# Altium schematic sheet Bandgap Reference.SchDoc("Bandgap Reference")
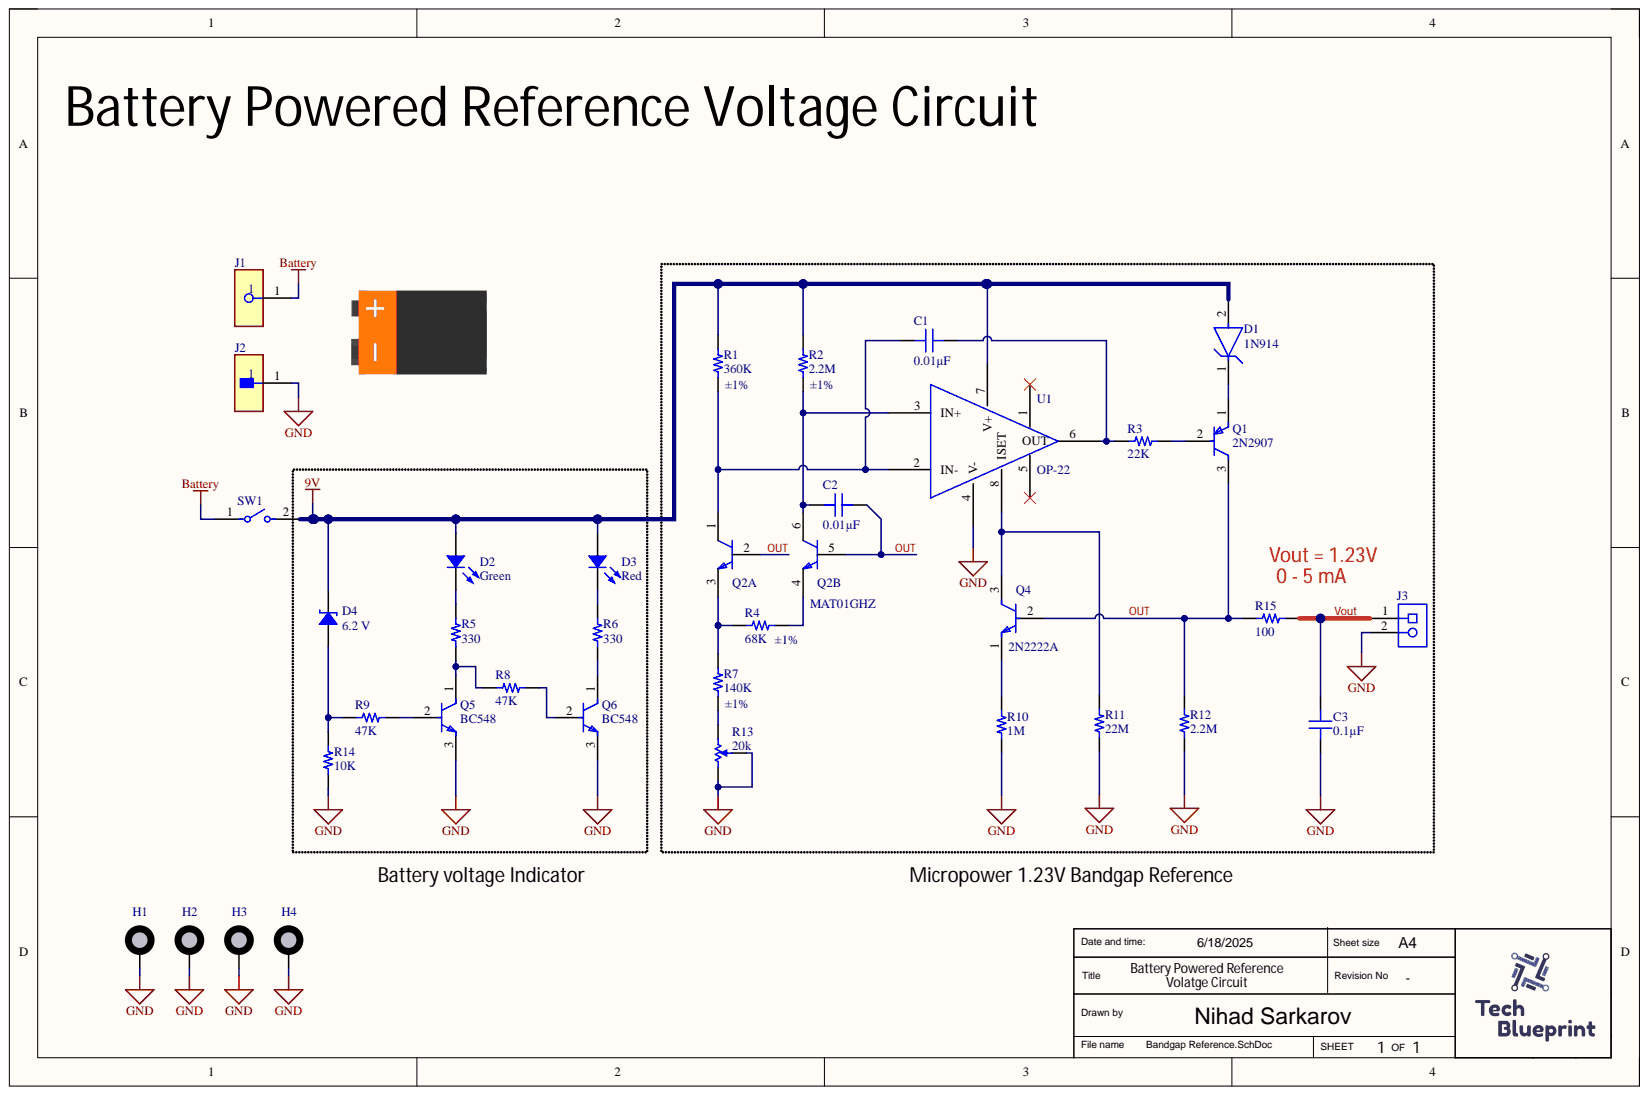
[diagram p0: Bandgap Reference.SchDoc]

## Parts
C1  Capacitance: 0.01µF  [CAP 1206_3216 - 0.8MM]  (hidden comment: 10000 pF)
C2  Capacitance: 0.01µF  [CAP 1206_3216 - 0.8MM]  (hidden comment: 10000 pF)
C3  0.1µF  [CAP 1206_3216 - 1.0MM]
D1  1N914  [FP-DO-213AA-MFG]
D2  Green  [LED 1206_3216 GREEN]
D3  Red  [LED 1206_3216 RED]
D4  Voltage - Zener (Nom) (Vz): 6.2 V  [DIODES SOT-323-3]  (hidden comment: BZX84W-B6V2F)
H1  Mounting Hole  [Mounting Hole]  (value not drawn)
H2  Mounting Hole  [Mounting Hole]  (value not drawn)
H3  Mounting Hole  [Mounting Hole]  (value not drawn)
H4  Mounting Hole  [Mounting Hole]  (value not drawn)
J1  594  [FP-594-MFG]  (value not drawn)
J2  593  [FP-593-MFG]  (value not drawn)
J3  1729128  [PHOE-1729128-2_V]  (value not drawn)
Q1  2N2907  [FP-TO-18-MFG]
Q2  MAT01GHZ  [FP-H-06-MFG]  (parts A,B)
Q4  2N2222A  [FP-2N2222A-MFG]
Q5  BC548  [FP-135AN-MFG]
Q6  BC548  [FP-135AN-MFG]
R1  360K  Tolerance: ±1%  [RES 1206_3216]
R2  2.2M  Tolerance: ±1%  [RES 1206_3216]
R3  22K  [RES 1206_3216]
R4  68K  Tolerance: ±1%  [RES 1206_3216]
R5  330  [RES 1206_3216]
R6  330  [RES 1206_3216]
R7  140K  Tolerance: ±1%  [RES 1206_3216]
R8  47K  [RES 1206_3216]
R9  47K  [RES 1206_3216]
R10  1M  [RES 1206_3216]
R11  22M  [RES 1206_3216]
R12  2.2M  [RES 1206_3216]
R13  Resistance: 20k  [BOUR-3313J-1_V]  (hidden comment: 3313J-1-203E)
R14  10K  [RES 1206_3216]
R15  100  [RES 1206_3216]
SW1  BD01  [FP-BD01-MFG]  (value not drawn)
U1  OP-22  [CERDIP8_300MC_MCH]

## Nets
9V: D1.2 D2.1 D3.1 D4.2 R1.2 R2.2 SW1.2 U1.7(V+)
Battery: J1.1 SW1.1
GND: C3.1 H1.1 H2.1 H3.1 H4.1 J2.1 J3.2 Q5.3 Q6.3 R10.1 R11.1 R12.1 R13.1 R13.2 R14.1 U1.4(V-)
OUT: C2.2 Q1.3 Q2.2 Q2.5 Q4.2 R12.2 R15.1
Vout: C3.2 J3.1 R15.2
NetC1_1: C1.1 Q2.1 R1.1 U1.2(IN-)
NetC1_2: C1.2 R3.1 U1.6(OUT)
NetC2_1: C2.1 Q2.6 R2.1 U1.3(IN+)
NetD1_1: D1.1 Q1.1
NetD2_2: D2.2 R5.2
NetD3_2: D3.2 R6.2
NetD4_1: D4.1 R9.1 R14.2
NetQ1_2: Q1.2 R3.2
NetQ2_3: Q2.3 R4.1 R7.2
NetQ2_4: Q2.4 R4.2
NetQ4_1: Q4.1 R10.2
NetQ4_3: Q4.3 R11.2 U1.8(ISET)
NetQ5_1: Q5.1 R5.1 R8.1
NetQ5_2: Q5.2 R9.2
NetQ6_1: Q6.1 R6.1
NetQ6_2: Q6.2 R8.2
NetR7_1: R7.1 R13.3

Not connected: U1 1 5

## Library data of the parts
C1 C2 = C1206C103KARECAUTO: CAP CER 1206 10NF 250V X7R 10%; manufacturer: KEMET; Database Table Name: Capacitors - Ceramic - 1206; Applications: Automotive, ESD Protection; Component Kind: Standard; componentlink1description: Datasheet; componentlink1url: https://api.kemet.com/component-edge/download/datasheet/C1206C103KARECAUTO.pdf; componentlink2description: DigiKey Link; componentlink2url: https://www.digikey.com/en/products/detail/kemet/C1206C103KARECAUTO/8563702; Device Package: 1206 (3216 Metric); lastupdated: 6/4/2024 7:10:29 PM; Library Name: Celestial Altium Library - altium_library.DbLib; Lifecycle Status: Active; Minimum Order: 1; Mounting Type: Surface Mount, MLCC; Operating Temperature: -55°C ~ 125°C; Package / Case: 1206 (3216 Metric); packaging: TapeAndReel; Part Number: C1206C103KARECAUTO; Part Status: Active; partid: 20579; price: 0.03; Ratings: AEC-Q200; series: ESD SMD Auto X7R; Size / Dimension: 0.126" L x 0.063" W (3.20mm x 1.60mm); Supplier 1: DigiKey; Supplier Part Number 1: 399-17174-1-ND; Temperature Coefficient: X7R; Thickness (Max): 0.035" (0.88mm); Tolerance: ±10%; value: 10000 pF; Voltage - Rated: 250V
C3 = LD065C104KAB2A: CAP CER 0.1UF 50V X7R 1206; manufacturer: AVX Corporation; Database Table Name: Capacitors - Ceramic - 1206; Tolerance: ±10%; Applications: General Purpose; Capacitance: 0.1µF; Component Kind: Standard; componentlink1description: Datasheet; componentlink1url: http://datasheets.avx.com/LD-Series.pdf; componentlink2description: DigiKey Link; componentlink2url: http://digikey.com/product-detail/en/avx-corporation/LD065C104KAB2A/478-4932-1-ND/1858878; Device Package: 1206 (3216 Metric); lastupdated: 9/2/2020 1:05:06 AM; Library Name: Celestial Altium Library - altium_library.DbLib; Lifecycle Status: Active; Minimum Order: 1; Mounting Type: Surface Mount, MLCC; Operating Temperature: -55°C ~ 125°C; Package / Case: 1206 (3216 Metric); packaging: TapeAndReel; Part Number: LD065C104KAB2A; Part Status: Active; partid: 18962; price: 0.15; series: LD; Size / Dimension: 0.126" L x 0.063" W (3.20mm x 1.60mm); Supplier 1: DigiKey; Supplier Part Number 1: 478-4932-1-ND; Temperature Coefficient: X7R; Thickness (Max): 0.037" (0.94mm); value: 0.1µF; Voltage - Rated: 50V
D1 = CMP-00252-10456399-1: JANTX1N914UR Signal Or Computer Diode Do-213Aa Rohs Compliant: Yes; Average Rectified Current: 200mA; Capacitance: 4pF; Element Configuration: Single; Forward Voltage: 1.2V; Max Forward Surge Current (Ifsm): 2A; Max Junction Temperature (Tj): 175°C; Max Repetitive Reverse Voltage (Vrrm): 75V; Peak Reverse Current: 25nA; Reverse Recovery Time: 5ns; Component Kind: Standard; Forward Current: 200mA; Height: 1.7mm; Library Name: Altium Content Vault; Lifecycle Status: Production; Max Operating Temperature: 175°C; Max Reverse Voltage (DC): 75V; Min Operating Temperature: -65°C; Mount: Surface Mount; NexarPartId: 10456399; Number of Pins: 2; Peak Non-Repetitive Surge Current: 2A; Radiation Hardening: No; Reverse Voltage: 75V; RoHS: Compliant; Schedule B: 8541100070
D2 = APT3216SGC: LED GREEN CLEAR CHIP SMD; Manufacturer: Kingbright; Configuration: Standard; Current - Test: 20mA; Database Table Name: LED - Indication; Lens Color: Colorless; Lens Size: 2.00mm x 1.60mm; Lens Style: Rectangle with Flat Top; Millicandela Rating: 12mcd; Viewing Angle: 120°; Voltage - Forward (Vf) (Typ): 2.2V; Wavelength - Dominant: 568nm; Wavelength - Peak: 565nm; Color: Green; Component Kind: Standard; ComponentLink1Description: Datasheet; ComponentLink1URL: http://www.kingbrightusa.com/images/catalog/SPEC/APT3216SGC.pdf; ComponentLink2Description: DigiKey Link; ComponentLink2URL: https://www.digikey.com/en/products/detail/kingbright/APT3216SGC/1747540; Device Package: 1206 (3216 Metric); Height (Max): 0.75mm; LastUpdated: 6/3/2024 7:59:11 PM; Lens Transparency: Clear; Library Name: Celestial Altium Library - altium_library.DbLib; Lifecycle Status: Active; Minimum Order: 1; Mounting Type: Surface Mount; Package / Case: 1206 (3216 Metric); Packaging: TapeAndReel; Part Number: APT3216SGC; Part Status: Active; PartId: 526; Price: 0.05; Series: -; Size / Dimension: 3.20mm L x 1.60mm W; Supplier 1: DigiKey; Supplier Device Package: Chip LED; Supplier Part Number 1: 754-1141-1-ND; Value: Green
D3 = HSMC-C350: LED RED CHIP SMD; Manufacturer: Broadcom Limited; Configuration: Standard; Current - Test: 20mA; Database Table Name: LED - Indication; Lens Color: 1.20mm; Lens Size: 1.10mm Dia; Lens Style: Round with Domed Top; Viewing Angle: 70°; Voltage - Forward (Vf) (Typ): 2V; Wavelength - Dominant: 630nm; Wavelength - Peak: 643nm; Color: Red; Component Kind: Standard; ComponentLink1Description: Datasheet; ComponentLink1URL: https://docs.broadcom.com/docs/HSMC-C350-DS100; ComponentLink2Description: DigiKey Link; ComponentLink2URL: https://www.digikey.com/en/products/detail/broadcom-limited/HSMC-C350/8509678; Device Package: 1206 (3216 Metric); Height (Max): 1.20mm; LastUpdated: 6/3/2024 8:00:41 PM; Library Name: Celestial Altium Library - altium_library.DbLib; Lifecycle Status: Active; Minimum Order: 1; Mounting Type: Surface Mount; Package / Case: 1206 (3216 Metric); Packaging: TapeAndReel; Part Number: HSMC-C350; Part Status: Active; PartId: 195; Price: 0.26; Series: -; Size / Dimension: 3.20mm L x 1.60mm W; Supplier 1: DigiKey; Supplier Device Package: Chip LED; Supplier Part Number 1: 516-4369-1-ND; Value: Red
D4 = BZX84W-B6V2F: DIODE ZENER 6.2V 275MW SOT323; Manufacturer: Nexperia USA Inc.; Current - Reverse Leakage @ Vr: 3 µA @ 4 V; Database Table Name: Diodes - Zener - Single; Impedance (Max) (Zzt): 10 Ohms; Tolerance: ±2%; Voltage - Forward (Vf) (Max) @ If: 900 mV @ 10 mA; Component Kind: Standard; ComponentLink1Description: Datasheet; ComponentLink1URL: https://assets.nexperia.com/documents/data-sheet/BZX84W_SER.pdf; ComponentLink2Description: DigiKey Link; ComponentLink2URL: https://www.digikey.com/en/products/detail/nexperia-usa-inc/BZX84W-B6V2F/9380695; LastUpdated: 6/3/2024 8:01:47 PM; Library Name: Celestial Altium Library - altium_library.DbLib; Lifecycle Status: Active; Minimum Order: 1; Mounting Type: Surface Mount; Operating Temperature: 150°C (TJ); Package / Case: SC-70, SOT-323; Packaging: TapeAndReel; Part Number: BZX84W-B6V2F; Part Status: Active; PartId: 809; Power - Max: 275 mW; Price: 0.02; Series: BZX84W; Supplier 1: DigiKey; Supplier Device Package: SOT-323; Supplier Part Number 1: 1727-8280-1-ND
J1 = CMP-19636-000029-1: BATTERY CONTACT SNAP 9V PC PIN; Body Material: Steel; Body Plating: Tin; Connector Type: Snap in; Gender: Female; Material Thickness: 0.15inch; Number of Batteries: 1; Component Kind: Standard; Contact Material: Steel; Contact Plating: Nickel; Contact Type: Snap-In; Lead Free: Lead Free; Length: 9.65mm; Library Name: Altium Content Vault; Material: Steel; Mount: Through Hole; Number of Cells: 1; Orientation: Right Angle; Package Quantity: 100; Packaging: Bulk; Reach SVHC Compliant: Yes; RoHS Compliant: Yes; Series: -; Termination: PC Pin; Thickness: 0.015inch
J2 = CMP-19636-000031-1: BATTERY CONTACT SNAP 9V PC PIN; Body Material: Steel; Body Plating: Tin; Connector Type: Snap in; Gender: Male; Material Thickness: 0.15inch; Number of Batteries: 1; Component Kind: Standard; Contact Material: Steel; Contact Plating: Nickel; Contact Type: Snap-In; Lead Free: Lead Free; Length: 9.65mm; Library Name: Altium Content Vault; Material: Steel; Mount: Through Hole; Number of Cells: 1; Orientation: Right Angle; Package Quantity: 100; Packaging: Bulk; Reach SVHC Compliant: Yes; RoHS Compliant: Yes; Series: -; Termination: PC Pin; Thickness: 0.015inch
J3 = CMP-2000-05815-1: PCB Terminal Block, 13.5 A, 400 V, 2-Pin THD, RoHS, Bulk; Manufacturer: Phoenix Contact; Current Rating: 13500mA; No. of Positions: 2; No. of Rows: 1; Package Version: 03/2015; Pitch: 5.08mm; Voltage Rating: 400V; Component Kind: Standard; Datasheet URL: https://datasheet.ciiva.com/16046/1729128-16046181.pdf; Datasheet Version: 12/2013; Library Name: Altium Content Vault; Manufacturer URL: http://www.phoenixcontact.com/; Mounting Technology: ThroughHole; Orientation: Horizontal; Package Description: 2-Pin Through Hole Device, Body 8.1 x 10.16 mm, Pitch 5.08 mm; Package Reference: 1729128-2; Package URL: https://www.phoenixcontact.com/online/portal/pi/pxc/product_detail_page/!ut/p/b1/3VdJjqNKFDxLH8AFycySyWY2ozFsEPOMMQaDOX1XedGL_1Vdm5YXJleknhSKeBkvI6EA8ncAo3AYoDhBQGco6KN7VURTdemj9us_IEKEZo_YHiPAEWEFmDGOHMORkqKYCORBZxgL7ZoaZYcbFFUZ3fqxSY6GOuxydWUuHqz1ynmMFygu583Bob0Ewr6Sd_l-5m-kAueHiB0jpRwZwe58wwtkpxtMYd_LmGcDRU02dRNEyWt6Y2ti5pSyJ_reIkzIulrqApdnbT11V5jnCKbYNCvhEM1MCpPByat_X379-iThf08CI5GfOPqfBeS3BRoBOdD55AKVv0iLwCMq-2BrzXWSGRH7pUGD9uwXQjiph3xL0DKQwdGa0JImiIOmBNOestqgwTHSSs2oOep-78apyXQBvNi8ZLaFjvjWvcaUEBu0MC6Wbe-d6mB0FAebo2lUWinqYaZs5DAYzV0RP9yitUjnGJ0iI9xhZkT42OCQNUJJhkgWINNQLP2JFP6OpMg3JCVg70jqHT0lvKOnhHf0lPuOnnLf0VPuWx6_dxwUIvwk5WMkT0kLL1mh61l2yZVSzG3pYV_bqo-mj6uMKwKwdnnW8Jh5RTOJzMOA5c-eJDaZQw4mTMPH02PvS_ZAqNVjVTo2WyYLkwH_KU1mmmG5kjRhDqPXLwwVHvVOjCM60-fpXvTDfMGW1PVFVS1r4e4yVDk4ZdNbkUHvqPqQSeian4UD39fNKmachqdJ1NJbyk8rXT9mXTvPk3oE5meulKGgiruPJek-4I9hTT6G8ZLOyRSm2RRVbThERQad5OS28hdt4YW4vG0stgYYzsWsK4_7y6DZMjJSCqri1kyiZR5vD7pwIrNR7QwPHTcLTI5qT7eRlpHrdGiupYlqQDJ7zy_CND8fWWBoLRXxk1RVcXiBQwbTD4Xi7KL-FuO94t9xaqweXzH; Packing: Bulk; PartNumber: 1729128; RoHS: TRUE
Q1 = CMP-00252-117134470-1: 2N2907 Small-Signal Bjt To-18 Rohs Compliant: Yes; Collector Base Voltage (VCBO): 60V; Collector Emitter Saturation Voltage: 1.6V; Emitter Base Voltage (VEBO): 5V; hFE Min: 100; Max Collector Current: 600mA; Max Junction Temperature (Tj): 200°C; Number of Elements: 1; Power Dissipation: 500mW; Case/Package: TO-18; Collector Emitter Breakdown Voltage: 60V; Collector Emitter Voltage (VCEO): 60V; Component Kind: Standard; Height: 5.33mm; Library Name: Altium Content Vault; Lifecycle Status: Production; Max Operating Temperature: 200°C; Min Operating Temperature: -65°C; NexarPartId: 117134470
Q2 = MAT01GHZ: TRANS 2NPN 45V 0.025A TO78-6; Element Configuration: Dual; hFE Min: 250; Max Collector Current: 25mA; Max Power Dissipation: 500mW; Transition Frequency: Mhz; Case/Package: TO-78; Collector Emitter Voltage (VCEO): 45V; Component Kind: Standard; Height: 4.7mm; Library Name: TC427IJA.SchLib; Max Operating Temperature: 125°C; Min Operating Temperature: -55°C; RoHS Compliant: Yes
Q4 = CMP-50385-000001-1: T-NPN SI- AUDIO VHF AMP; Collector Emitter Breakdown Voltage: 40V; Max Power Dissipation: 1.2W; Polarity: NPN; Transition Frequency: 300MHz; Case/Package: TO-18; Collector Emitter Voltage (VCEO): 40V; Component Kind: Standard; Lead Free: Contains Lead; Library Name: Altium Content Vault; Max Operating Temperature: 200°C; Number of Pins: 3; REACH SVHC: No SVHC
Q5 Q6 = CMP-00278-84324557-1: BC548 Bulk Through Hole NPN Single Bipolar (BJT) Transistor 110 @ 2mA 5V 100mA 500mW 300MHz; Collector Base Voltage (VCBO): 30V; Collector Emitter Saturation Voltage: 250mV; hFE Min: 110; Number of Elements: 1; Case/Package: TO-92; Collector Emitter Breakdown Voltage: 30V; Collector Emitter Voltage (VCEO): 30V; Component Kind: Standard; Current Rating: 100mA; Element Configuration: Single; Emitter Base Voltage (VEBO): 5V; Frequency: 300MHz; Gain Bandwidth Product: 300MHz; Lead Free: Lead Free; Library Name: Altium Content Vault; Max Collector Current: 100mA; Max Frequency: 300MHz; Max Operating Temperature: 150°C; Max Power Dissipation: 500mW; Min Operating Temperature: -65°C; Mount: Through Hole; NexarPartId: 84324557; Number of Pins: 3; Packaging: Bulk; Polarity: NPN; Power Dissipation: 500mW; Radiation Hardening: No; REACH SVHC: No SVHC; RoHS: Compliant; Termination: Through Hole; Transition Frequency: 300MHz; Voltage Rating (DC): 30V; Weight: 200mg
R1 = 3430B2F360KTDF: 3430 B2 1% 360K 100PPM 1K RL; Manufacturer: TE Connectivity Passive Product; Database Table Name: Resistors - Surface Mount - 1206; Power (Watts): 1.5W; Resistance: 360 kOhms; Temperature Coefficient: ±100ppm/°C; Value: 360 kOhms; Component Kind: Standard; ComponentLink2Description: DigiKey Link; ComponentLink2URL: https://www.digikey.com/en/products/detail/te-connectivity-passive-product/3430B2F360KTDF/17764161; Composition: Thick Film; Device Package: 1206 (3216 Metric); Features: Automotive AEC-Q200; Height - Seated (Max): 0.026" (0.65mm); LastUpdated: 2/9/2024 8:52:53 PM; Library Name: Celestial Altium Library - altium_library.DbLib; Lifecycle Status: Active; Minimum Order: 1; Number of Terminations: 2; Operating Temperature: -55°C ~ 155°C; Package / Case: 1206 (3216 Metric); Packaging: TapeAndReel; Part Number: 3430B2F360KTDF; Part Status: Active; PartId: 77146; Price: 0.07; Ratings: AEC-Q200; Series: 3430; Size / Dimension: 0.061" L x 0.118" W (1.55mm x 3.00mm); Supplier 1: DigiKey; Supplier Device Package: 0612; Supplier Part Number 1: 1712-3430B2F360KTDFCT-ND
R2 R12 = ERJ-PM8F2204V: RES 2.2M OHM 1% 0.66W 1206; Manufacturer: Panasonic Electronic Components; Database Table Name: Resistors - Surface Mount - 1206; Power (Watts): 0.667W, 2/3W; Resistance: 2.2 MOhms; Temperature Coefficient: ±100ppm/°C; Value: 2.2 MOhms; Component Kind: Standard; ComponentLink1Description: Datasheet; ComponentLink1URL: https://industrial.panasonic.com/cdbs/www-data/pdf/RDO0000/AOA0000C331.pdf; ComponentLink2Description: DigiKey Link; ComponentLink2URL: https://www.digikey.com/en/products/detail/panasonic-electronic-components/ERJ-PM8F2204V/16265782; Composition: Thick Film; Device Package: 1206 (3216 Metric); Features: Automotive AEC-Q200, Pulse Withstanding; Height - Seated (Max): 0.028" (0.70mm); LastUpdated: 2/9/2024 8:53:14 PM; Library Name: Celestial Altium Library - altium_library.DbLib; Lifecycle Status: Active; Minimum Order: 1; Number of Terminations: 2; Operating Temperature: -55°C ~ 155°C; Package / Case: 1206 (3216 Metric); Packaging: TapeAndReel; Part Number: ERJ-PM8F2204V; Part Status: Active; PartId: 68714; Price: 0.03; Ratings: AEC-Q200; Series: ERJ-PM8; Size / Dimension: 0.126" L x 0.063" W (3.20mm x 1.60mm); Supplier 1: DigiKey; Supplier Device Package: 1206; Supplier Part Number 1: 10-ERJ-PM8F2204VCT-ND
  R12: Tolerance: ±1%
R3 = 3430B2F22KTDF: 3430 B2 1% 22K 100PPM 1K RL; Manufacturer: TE Connectivity Passive Product; Database Table Name: Resistors - Surface Mount - 1206; Power (Watts): 1.5W; Resistance: 22 kOhms; Temperature Coefficient: ±100ppm/°C; Value: 22 kOhms; Component Kind: Standard; ComponentLink2Description: DigiKey Link; ComponentLink2URL: https://www.digikey.com/en/products/detail/te-connectivity-passive-product/3430B2F22KTDF/17763028; Composition: Thick Film; Device Package: 1206 (3216 Metric); Features: Automotive AEC-Q200; Height - Seated (Max): 0.026" (0.65mm); LastUpdated: 2/9/2024 8:52:52 PM; Library Name: Celestial Altium Library - altium_library.DbLib; Lifecycle Status: Active; Minimum Order: 1; Number of Terminations: 2; Operating Temperature: -55°C ~ 155°C; Package / Case: 1206 (3216 Metric); Packaging: TapeAndReel; Part Number: 3430B2F22KTDF; Part Status: Active; PartId: 77235; Price: 0.07; Ratings: AEC-Q200; Series: 3430; Size / Dimension: 0.061" L x 0.118" W (1.55mm x 3.00mm); Supplier 1: DigiKey; Supplier Device Package: 0612; Supplier Part Number 1: 1712-3430B2F22KTDFCT-ND; Tolerance: ±1%
R4 = 3430B2F68KTDF: 3430 B2 1% 68K 100PPM 1K RL; Manufacturer: TE Connectivity Passive Product; Database Table Name: Resistors - Surface Mount - 1206; Power (Watts): 1.5W; Resistance: 68 kOhms; Temperature Coefficient: ±100ppm/°C; Value: 68 kOhms; Component Kind: Standard; ComponentLink2Description: DigiKey Link; ComponentLink2URL: https://www.digikey.com/en/products/detail/te-connectivity-passive-product/3430B2F68KTDF/17762904; Composition: Thick Film; Device Package: 1206 (3216 Metric); Features: Automotive AEC-Q200; Height - Seated (Max): 0.026" (0.65mm); LastUpdated: 2/9/2024 8:52:42 PM; Library Name: Celestial Altium Library - altium_library.DbLib; Lifecycle Status: Active; Minimum Order: 1; Number of Terminations: 2; Operating Temperature: -55°C ~ 155°C; Package / Case: 1206 (3216 Metric); Packaging: TapeAndReel; Part Number: 3430B2F68KTDF; Part Status: Active; PartId: 77735; Price: 0.07; Ratings: AEC-Q200; Series: 3430; Size / Dimension: 0.061" L x 0.118" W (1.55mm x 3.00mm); Supplier 1: DigiKey; Supplier Device Package: 0612; Supplier Part Number 1: 1712-3430B2F68KTDFCT-ND
R5 R6 = RCS1206330RJNEA: RCS1206 200 330R 5% ET1 E3; Manufacturer: Vishay Dale; Database Table Name: Resistors - Surface Mount - 1206; Power (Watts): 0.5W, 1/2W; Resistance: 330 Ohms; Temperature Coefficient: ±200ppm/°C; Value: 330 Ohms; Component Kind: Standard; ComponentLink1Description: Datasheet; ComponentLink1URL: http://www.vishay.com/docs/20065/rcse3.pdf; ComponentLink2Description: DigiKey Link; ComponentLink2URL: https://www.digikey.com/en/products/detail/vishay-dale/RCS1206330RJNEA/10059691; Composition: Thick Film; Device Package: 1206 (3216 Metric); Features: Automotive AEC-Q200, Pulse Withstanding; Height - Seated (Max): 0.026" (0.65mm); LastUpdated: 2/9/2024 8:53:54 PM; Library Name: Celestial Altium Library - altium_library.DbLib; Lifecycle Status: Active; Minimum Order: 1; Number of Terminations: 2; Operating Temperature: -55°C ~ 155°C; Package / Case: 1206 (3216 Metric); Packaging: TapeAndReel; Part Number: RCS1206330RJNEA; Part Status: Active; PartId: 40742; Price: 0.03; Ratings: AEC-Q200; Series: RCS e3; Size / Dimension: 0.126" L x 0.063" W (3.20mm x 1.60mm); Supplier 1: DigiKey; Supplier Device Package: 1206; Supplier Part Number 1: 541-10625-1-ND; Tolerance: ±5%
R7 = RMCF1206FT140K: RES 140K OHM 1% 1/4W 1206; Manufacturer: Stackpole Electronics Inc; Database Table Name: Resistors - Surface Mount - 1206; Power (Watts): 0.25W, 1/4W; Resistance: 140 kOhms; Temperature Coefficient: ±100ppm/°C; Value: 140 kOhms; Component Kind: Standard; ComponentLink1Description: Datasheet; ComponentLink1URL: https://www.seielect.com/catalog/sei-rmcf_rmcp.pdf; ComponentLink2Description: DigiKey Link; ComponentLink2URL: https://www.digikey.com/en/products/detail/stackpole-electronics-inc/RMCF1206FT140K/1759984; Composition: Thick Film; Device Package: 1206 (3216 Metric); Features: Automotive AEC-Q200; Height - Seated (Max): 0.028" (0.70mm); LastUpdated: 2/9/2024 8:54:07 PM; Library Name: Celestial Altium Library - altium_library.DbLib; Lifecycle Status: Active; Minimum Order: 1; Number of Terminations: 2; Operating Temperature: -55°C ~ 155°C; Package / Case: 1206 (3216 Metric); Packaging: TapeAndReel; Part Number: RMCF1206FT140K; Part Status: Active; PartId: 36791; Price: 0.01; Ratings: AEC-Q200; Series: RMCF; Size / Dimension: 0.126" L x 0.063" W (3.20mm x 1.60mm); Supplier 1: DigiKey; Supplier Device Package: 1206; Supplier Part Number 1: 738-RMCF1206FT140KCT-ND
R8 R9 = RCL061247K0JNEA: RES SMD 47K OHM 3/4W 1206 WIDE; Manufacturer: Vishay Dale; Database Table Name: Resistors - Surface Mount - 1206; Power (Watts): 0.75W, 3/4W; Resistance: 47 kOhms; Temperature Coefficient: ±200ppm/°C; Tolerance: ±5%; Value: 47 kOhms; Component Kind: Standard; ComponentLink1Description: Datasheet; ComponentLink1URL: http://www.vishay.com/docs/20046/rcle3.pdf; ComponentLink2Description: DigiKey Link; ComponentLink2URL: https://www.digikey.com/en/products/detail/vishay-dale/RCL061247K0JNEA/2587667; Composition: Thick Film; Device Package: 1206 (3216 Metric); Features: Automotive AEC-Q200; Height - Seated (Max): 0.026" (0.65mm); LastUpdated: 2/9/2024 8:53:59 PM; Library Name: Celestial Altium Library - altium_library.DbLib; Lifecycle Status: Active; Minimum Order: 1; Number of Terminations: 2; Operating Temperature: -55°C ~ 155°C; Package / Case: 1206 (3216 Metric); Packaging: TapeAndReel; Part Number: RCL061247K0JNEA; Part Status: Active; PartId: 60527; Price: 0.03; Ratings: AEC-Q200; Series: RCL; Size / Dimension: 0.063" L x 0.126" W (1.60mm x 3.20mm); Supplier 1: DigiKey; Supplier Device Package: 0612; Supplier Part Number 1: 541-2376-1-ND
R10 = 3430B2F1M0TDF: 3430 B2 1% 1M0 100PPM 1K RL; Manufacturer: TE Connectivity Passive Product; Database Table Name: Resistors - Surface Mount - 1206; Power (Watts): 1.5W; Resistance: 1 MOhms; Temperature Coefficient: ±100ppm/°C; Value: 1 MOhms; Component Kind: Standard; ComponentLink2Description: DigiKey Link; ComponentLink2URL: https://www.digikey.com/en/products/detail/te-connectivity-passive-product/3430B2F1M0TDF/17763916; Composition: Thick Film; Device Package: 1206 (3216 Metric); Features: Automotive AEC-Q200; Height - Seated (Max): 0.026" (0.65mm); LastUpdated: 2/9/2024 8:52:45 PM; Library Name: Celestial Altium Library - altium_library.DbLib; Lifecycle Status: Active; Minimum Order: 1; Number of Terminations: 2; Operating Temperature: -55°C ~ 155°C; Package / Case: 1206 (3216 Metric); Packaging: TapeAndReel; Part Number: 3430B2F1M0TDF; Part Status: Active; PartId: 77560; Price: 0.07; Ratings: AEC-Q200; Series: 3430; Size / Dimension: 0.061" L x 0.118" W (1.55mm x 3.00mm); Supplier 1: DigiKey; Supplier Device Package: 0612; Supplier Part Number 1: 1712-3430B2F1M0TDFCT-ND; Tolerance: ±1%
R11 = CRG1206F22M: RES SMD 22M OHM 1% 1/4W 1206; Manufacturer: TE Connectivity Passive Product; Database Table Name: Resistors - Surface Mount - 1206; Power (Watts): 0.25W, 1/4W; Resistance: 22 MOhms; Temperature Coefficient: ±100ppm/°C; Value: 22 MOhms; Component Kind: Standard; ComponentLink1Description: Datasheet; ComponentLink1URL: https://www.te.com/commerce/DocumentDelivery/DDEController?Action=srchrtrv&DocNm=1773204&DocType=DS&DocLang=English; ComponentLink2Description: DigiKey Link; ComponentLink2URL: https://www.digikey.com/en/products/detail/te-connectivity-passive-product/CRG1206F22M/2381622; Composition: Thick Film; Device Package: 1206 (3216 Metric); Height - Seated (Max): 0.026" (0.65mm); LastUpdated: 2/9/2024 8:52:55 PM; Library Name: Celestial Altium Library - altium_library.DbLib; Lifecycle Status: Active; Minimum Order: 1; Number of Terminations: 2; Operating Temperature: -55°C ~ 155°C; Package / Case: 1206 (3216 Metric); Packaging: TapeAndReel; Part Number: CRG1206F22M; Part Status: Active; PartId: 76687; Price: 0.06; Series: CRG, Neohm; Size / Dimension: 0.122" L x 0.061" W (3.10mm x 1.55mm); Supplier 1: DigiKey; Supplier Device Package: 1206; Supplier Part Number 1: 1712-CRG1206F22MCT-ND; Tolerance: ±1%
R13 = CMP-2000-05586-1: 3 mm Trimpot(R) Trimming Potentiometer, 20 KOhm, +/- 20%, 0.125 W, -55 to 125 degC, 3-Pin SMD, RoHS, Tape and Reel; Manufacturer: Bourns; Angle, Mechanical: 250°; Brand/Series: Trimpot®3313-SealedSeries; Dielectric Strength: 500VAC; Insulation Resistance: 100Megohms(Min.)@500VDC; Load Life: 1000hr@0.125W,70°C; Material, Element: Cermet; Number of Turns: 1; Power, Rating: 0.125W; Resistance, Insulation: 100Megohms; Resolution: Infinite; Rotational Life: 100Cycles; Standards: RoHSCompliant; Temperature Coefficient: ±100ppm/°C; Temperature, Operating, Maximum: +125°C; Temperature, Operating, Minimum: -55°C; Torque: 50g-cm; Component Kind: Standard; Datasheet URL: http://www.bourns.com/docs/Product-Datasheets/3313.pdf; Datasheet Version: 05/2016; Dimensions: 0.126"Lx0.138"Wx0.087"H; Library Name: Altium Content Vault; Manufacturer URL: http://www.bourns.com/; Mounting Technology: SurfaceMount; Mounting Type: SurfaceMount; Package Description: 3-Pin Surface Mount Device, Body 3.5 x 3.2 mm, Pitch 1.15 mm; Package Reference: 3313J-1; Termination: SMD; Tolerance: ±20%; Type: Trimmer
R14 = RCC120610K0JNEA: RCC1206 200 10K 5% ET1 E3; Manufacturer: Vishay Dale; Database Table Name: Resistors - Surface Mount - 1206; Power (Watts): 0.5W, 1/2W; Resistance: 10 kOhms; Temperature Coefficient: ±200ppm/°C; Value: 10 kOhms; Component Kind: Standard; ComponentLink1Description: Datasheet; ComponentLink1URL: https://www.vishay.com/doc?20066; ComponentLink2Description: DigiKey Link; ComponentLink2URL: https://www.digikey.com/en/products/detail/vishay-dale/RCC120610K0JNEA/13667093; Composition: Thick Film; Device Package: 1206 (3216 Metric); Features: Automotive AEC-Q200; Height - Seated (Max): 0.024" (0.60mm); LastUpdated: 2/9/2024 8:53:21 PM; Library Name: Celestial Altium Library - altium_library.DbLib; Lifecycle Status: Active; Minimum Order: 1; Number of Terminations: 2; Operating Temperature: -55°C ~ 155°C; Package / Case: 1206 (3216 Metric); Packaging: TapeAndReel; Part Number: RCC120610K0JNEA; Part Status: Active; PartId: 58363; Price: 0.03; Ratings: AEC-Q200; Series: RCC e3; Size / Dimension: 0.126" L x 0.063" W (3.20mm x 1.60mm); Supplier 1: DigiKey; Supplier Device Package: 1206; Supplier Part Number 1: 541-RCC120610K0JNEACT-ND; Tolerance: ±5%
R15 = 3430B2F100RTDF: 3430 B2 1% 100R 100PPM 1K RL; Manufacturer: TE Connectivity Passive Product; Database Table Name: Resistors - Surface Mount - 1206; Power (Watts): 1.5W; Resistance: 100 Ohms; Temperature Coefficient: ±100ppm/°C; Value: 100 Ohms; Component Kind: Standard; ComponentLink2Description: DigiKey Link; ComponentLink2URL: https://www.digikey.com/en/products/detail/te-connectivity-passive-product/3430B2F100RTDF/17764114; Composition: Thick Film; Device Package: 1206 (3216 Metric); Features: Automotive AEC-Q200; Height - Seated (Max): 0.026" (0.65mm); LastUpdated: 2/9/2024 8:52:45 PM; Library Name: Celestial Altium Library - altium_library.DbLib; Lifecycle Status: Active; Minimum Order: 1; Number of Terminations: 2; Operating Temperature: -55°C ~ 155°C; Package / Case: 1206 (3216 Metric); Packaging: TapeAndReel; Part Number: 3430B2F100RTDF; Part Status: Active; PartId: 77604; Price: 0.07; Ratings: AEC-Q200; Series: 3430; Size / Dimension: 0.061" L x 0.118" W (1.55mm x 3.00mm); Supplier 1: DigiKey; Supplier Device Package: 0612; Supplier Part Number 1: 1712-3430B2F100RTDFCT-ND; Tolerance: ±1%
SW1 = CMP-10033-000004-1: SWITCH SLIDE DIP SPST 100MA 5V; Actuator Length: 0.9mm; Actuator Material: Nylon; Actuator Type: Slide (Standard); Approvals: UL; Body Material: PBT; Capacitance: 5pF; Contact Current Rating: 25mA; Electrical Life: 10000Cycles; Max Voltage Rating (DC): 25V; Number of Circuits: 1; Pitch: 0.1inch; Washable: Yes; Case/Package: DIP; Component Kind: Standard; Contact Material: Bronze; Contact Plating: Gold; Current Rating: 100mA; Depth: 9.65mm; Lead Free: Lead Free; Length: 0.18inch; Library Name: Altium Content Vault; Life (Cycles): 10000Cycles; Material: Nylon; Max Current Rating: 100mA; Max Operating Temperature: 85°C; Mechanical Life: 10000Cycles; Min Operating Temperature: -40°C; Mount: Through Hole; Number of Poles: 1; Number of Positions: 1; Orientation: Straight; Package Quantity: 115; Packaging: Rail/Tube; Radiation Hardening: No; REACH SVHC: No SVHC; RoHS Compliant: Yes; Series: BD; Terminal Pitch: 2.54mm; Termination: PC Pin; Throw Configuration: SPST; Voltage Rating (DC): 5V; Width: 9.65mm
U1 = OP-22: Programmable micropower operational amplifier; Mfr_Name: Analog Devices; Component Kind: Standard; ComponentLink1Description: Datasheet; ComponentLink1URL: https://bg-electronics.de/datenblaetter/Schaltkreise/OP22.pdf; Library Name: TC427IJA.SchLib; RefDes: RefDes; Type: Type
H1-H4 = Mounting Hole: Component Kind: Standard; Library Name: TC427IJA.SchLib
